# Altium schematic sheet 07 Audio.SchDoc
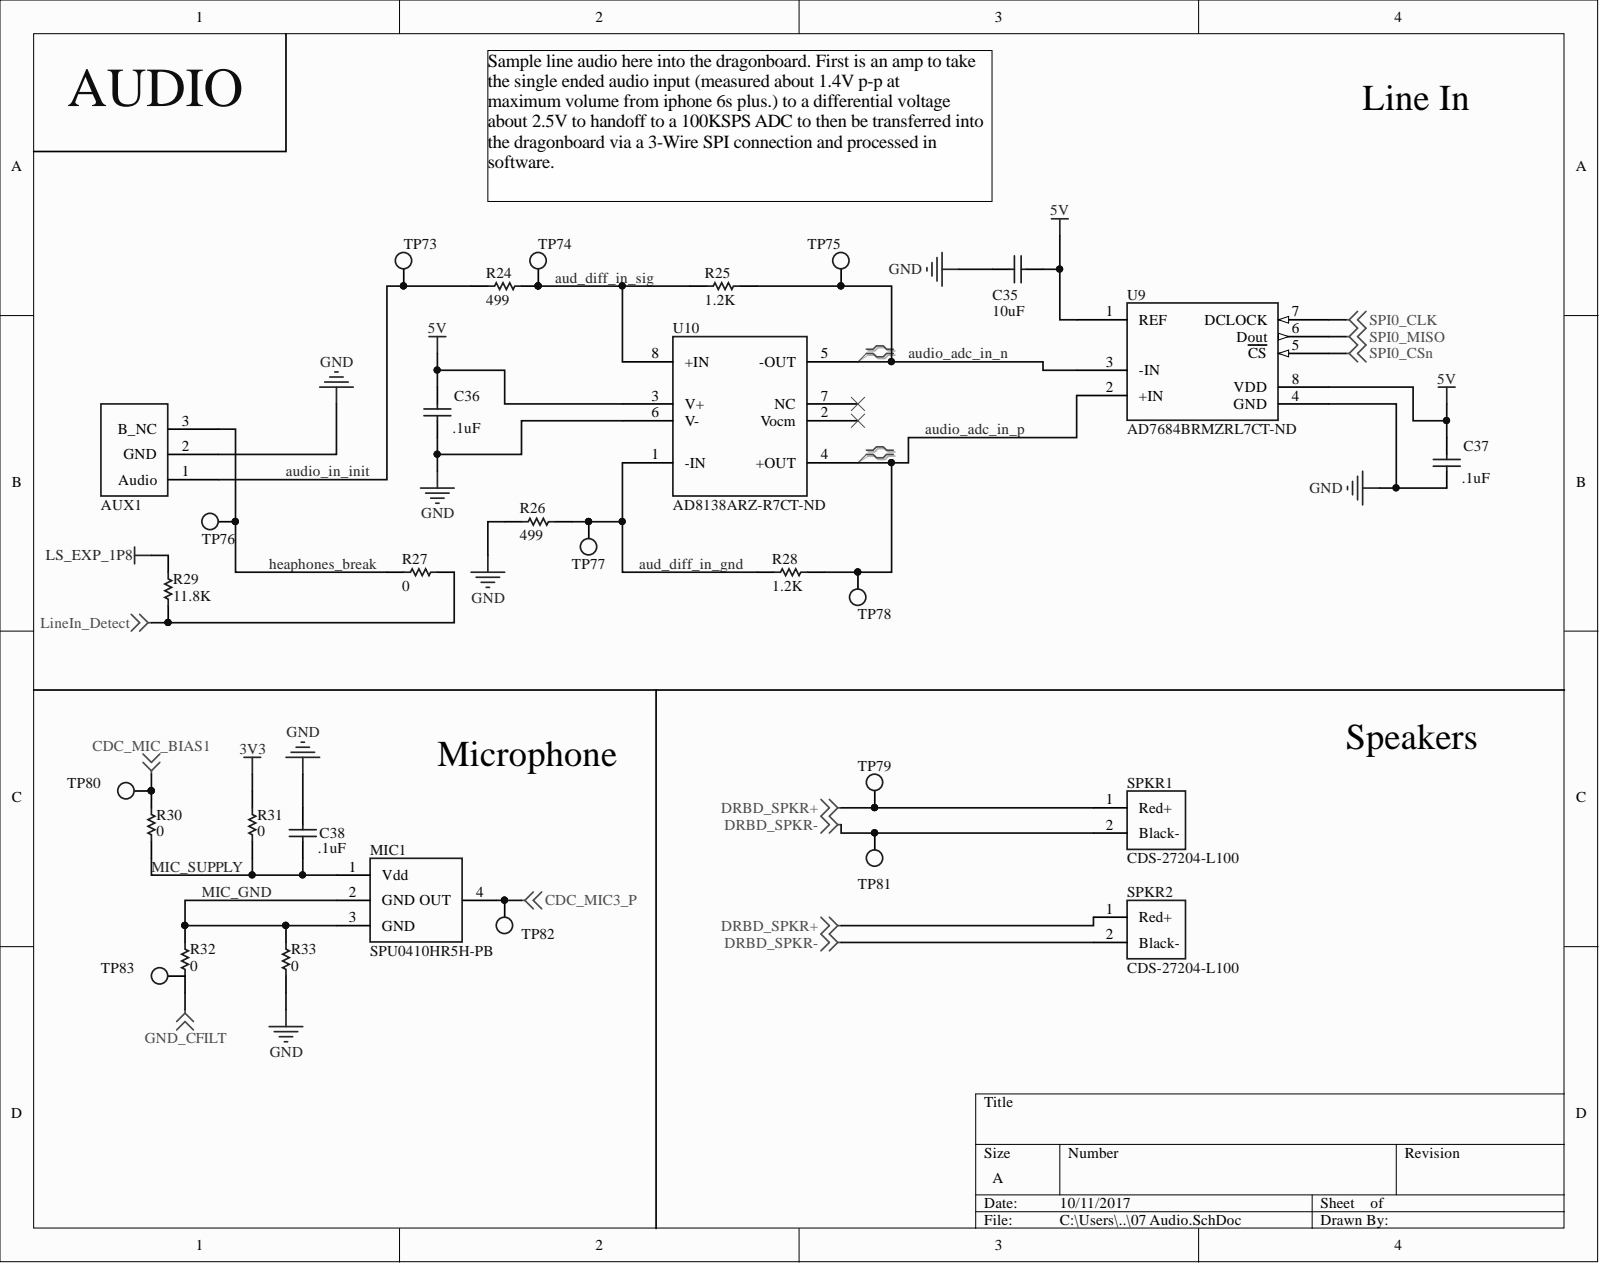
[diagram p0: 07 Audio.SchDoc]

## Parts
AUX1  CP-3523MJCT-ND  [Audio_Conn_Mono]  (value not drawn)
C35  value 10uF  Capacitance: 10uF  [CAPC2012X135N]  (hidden comment: CAP_0805_10u_16V)
C36  value .1uF  [CAPC2012X135N]  (hidden comment: CAP_0805_.1u_250V)
C37  value .1uF  [CAPC2012X135N]  (hidden comment: CAP_0805_.1u_250V)
C38  value .1uF  [CAPC2012X135N]  (hidden comment: CAP_0805_.1u_250V)
MIC1  SPU0410HR5H-PB  [MIC_FP]
R24  RES_0805_499  [RES0805]  (value not drawn)
R25  Resistance: 1.2K  [RES0805]  (hidden comment: RES_0805_1.2K)
R26  RES_0805_499  [RES0805]  (value not drawn)
R27  RES_0805_JMP  [RES0805]  (value not drawn)
R28  Resistance: 1.2K  [RES0805]  (hidden comment: RES_0805_1.2K)
R29  RES_0805_11.8K  [RES0805]  (value not drawn)
R30  RES_0805_JMP  [RES0805]  (value not drawn)
R31  RES_0805_JMP  [RES0805]  (value not drawn)
R32  RES_0805_JMP  [RES0805]  (value not drawn)
R33  RES_0805_JMP  [RES0805]  (value not drawn)
SPKR1  CDS-27204-L100  [Speaker_Header]
SPKR2  CDS-27204-L100  [Speaker_Header]
TP73  TP  [TestPoint_1206]
TP74  TP  [TestPoint_1206]
TP75  TP  [TestPoint_1206]
TP76  TP  [TestPoint_1206]
TP77  TP  [TestPoint_1206]
TP78  TP  [TestPoint_1206]
TP79  TP  [TestPoint_1206]
TP80  TP  [TestPoint_1206]
TP81  TP  [TestPoint_1206]
TP82  TP  [TestPoint_1206]
TP83  TP  [TestPoint_1206]
U9  AD7684BRMZRL7CT-ND  [MSOP8]
U10  AD8138ARZ-R7CT-ND  [SOIC127P600X175-8N]

## Nets
3V3: R31.2
5V: C35.1 C36.2 C37.2 U9.1(REF) U9.8(VDD) U10.3(V+)
CDC_MIC3_P: MIC1.4(OUT) TP82.1
CDC_MIC_BIAS1: R30.2 TP80.1
DRBD_SPKR+: SPKR1.1 SPKR2.1 TP79.1
DRBD_SPKR-: SPKR1.2 SPKR2.2 TP81.1
GND: AUX1.2 C35.2 C36.1 C37.1 C38.2 R26.1 R33.1 U9.4(GND) U10.6(V-)
GND_CFILT: R32.1
LineIn_Detect: R27.2 R29.1
LS_EXP_1P8: R29.2
SPI0_CLK: U9.7(DCLOCK)
SPI0_CSn: U9.5(CS)
SPI0_MISO: U9.6(Dout)
aud_diff_in_gnd: R26.2 R28.1 TP77.1 U10.1(-IN)
aud_diff_in_sig: R24.2 R25.1 TP74.1 U10.8(+IN)
audio_adc_in_n: R25.2 TP75.1 U9.3(-IN) U10.5(-OUT)
audio_adc_in_p: R28.2 TP78.1 U9.2(+IN) U10.4(+OUT)
audio_in_init: AUX1.1 R24.1 TP73.1
heaphones_break: AUX1.3 R27.1 TP76.1
MIC_GND: MIC1.2(GND) MIC1.3(GND) R32.2 R33.2
MIC_SUPPLY: C38.1 MIC1.1(Vdd) R30.1 R31.1

Not connected: TP83 1; U10 2(Vocm) 7(NC)

## Library data of the parts
AUX1 = CP-3523MJCT-ND: 3.50mm (0.141", 1/8", Mini Plug) - Headphone Phone Jack Mono Connector Solder; Manufacturer: CUI; Manufacturer Part Number: MJ-3523-SMT-TR; Current Rating: 1A; Gender: Female; Shielding: Unshielded; Case/Package: SMD/SMT; Component Kind: Standard; Contact Plating: Silver; Lead Free: Lead Free; Packaging: Cut Tape; Pricing 1: 1=1.02, 10=0.9, 25=0.8476, 50=0.8122, 100=0.7768, 250=0.7062 (USD); Stock 1: 44959; Supplier 1: Digi-Key; Supplier Part Number 1: CP-3523MJCT-ND; Termination: Solder; Library Name: schlib_truman.SchLib
C35 = CAP_0805_10u_16V: Cap Ceramic 10uF 16V X5R 10% SMD 0805 85C T/R; Manufacturer: Johanson Dielectrics; Manufacturer Part Number: 160R15X106KV4E; Case/Package: 0805; Case Code (Imperial): 0805; Case Code (Metric): 2012; Component Kind: Standard; ComponentLink1Description: https://datasheet.ciiva.com/26948/getdatasheetpartid-132904-26948555.pdf; ComponentLink1URL: https://datasheet.ciiva.com/26948/getdatasheetpartid-132904-26948555.pdf; Depth: 1.27mm; Dielectric: X5R; Height: 1.27mm; Length: 2.03mm; Max Operating Temperature: 85°C; Min Operating Temperature: -55°C; Mount: Surface Mount; Number of Pins: 2; Package Quantity: 2000; Packaging: Tape and Reel; Pricing 1: 1=0.55, 10=0.387, 100=0.2552, 500=0.189, 1000=0.1607 (USD); Radiation Hardening: No; REACH SVHC: No SVHC; Stock 1: 36636; Supplier 1: Digi-Key; Supplier Part Number 1: 709-1229-1-ND; Termination: SMD/SMT; Thickness: 0.06inch; Tolerance: 10%; Voltage Rating: 16V; Voltage Rating (DC): 16V; Weight: 0.000194oz; Width: 1.27mm; Library Name: schlib_truman.SchLib
C36-C38 = CAP_0805_.1u_250V: Cap Ceramic 0.1uF 250V X7T 10% SMD 0805 125C Plastic T/R; Manufacturer: TDK; Manufacturer Part Number: C2012X7T2E104K125AE; Capacitance: 100nF; Case/Package: 0805; Case Code (Imperial): 0805; Case Code (Metric): 2012; Component Kind: Standard; ComponentLink1Description: https://datasheet.ciiva.com/24219/c2012x7t2e104k125ae-265154-24219519.pdf; ComponentLink1URL: https://datasheet.ciiva.com/24219/c2012x7t2e104k125ae-265154-24219519.pdf; Composition: Ceramic; Depth: 1.25mm; Dielectric: X7T; Height: 1.25mm; Length: 2mm; Max Operating Temperature: 125°C; Min Operating Temperature: -55°C; Package Quantity: 1; Packaging: Tape and Reel; Pricing 1: 1=0.37, 10=0.25, 100=0.1419, 500=0.1002, 1000=0.0877 (USD); REACH SVHC: No SVHC; Stock 1: 30376; Supplier 1: Digi-Key; Supplier Part Number 1: 445-8883-1-ND; Termination: SMD/SMT; Thickness: 0.049inch; Tolerance: 10%; Voltage Rating: 250V; Voltage Rating (DC): 250V; Weight: 0.000194oz; Width: 0.05inch; Library Name: schlib_truman.SchLib
MIC1 = SPU0410HR5H-PB: MIC MEMS ANALOG OMNI -42DB; Manufacturer: Knowles; Direction: Omnidirectional; Impedance: 300R; Operating Supply Current: 250uA; Shape: Rectangular; Signal to Noise Ratio (SNR): 59dB; Voltage Rating: 3.6V; Component Kind: Standard; ComponentLink1Description: https://datasheet.ciiva.com/5300/spu0410hr5h-58393-5300133.pdf; ComponentLink1URL: https://datasheet.ciiva.com/5300/spu0410hr5h-58393-5300133.pdf; ComponentLink2Description: https://datasheet.ciiva.com/1/spu0410hr5h-pb-1958.pdf; ComponentLink2URL: https://datasheet.ciiva.com/1/spu0410hr5h-pb-1958.pdf; ComponentLink3Description: https://datasheet.ciiva.com/1/spu0410hr5h-pb-1959.pdf; ComponentLink3URL: https://datasheet.ciiva.com/1/spu0410hr5h-pb-1959.pdf; Depth: 1.2mm; Height: 1.2mm; Length: 3.76mm; Manufacturer Part Number: SPU0410HR5H-PB; Package Quantity: 1200; Packaging: Tape and Reel; Pricing 1: 1=0.94, 10=0.806, 25=0.6476, 50=0.5756, 100=0.5036, 250=0.4892, 500=0.4748 (USD); Stock 1: 176050; Supplier 1: Digi-Key; Supplier Part Number 1: 423-1138-1-ND; Termination: SMD/SMT; Width: 2.95mm; Library Name: schlib_truman.SchLib
R24 R26 = RES_0805_499: Res Thick Film 0805 499 Ohm 1% 0.125W(1/8W) ±100ppm/C Molded SMD Automotive T/R; Manufacturer: Stackpole Electronics; Manufacturer Part Number: RMCF0805FT499R; Max Power Dissipation: 125mW; Resistance: 499; Temperature Coefficient: 100ppm/°C; Case/Package: 0805; Case Code (Imperial): 0805; Case Code (Metric): 2012; Component Kind: Standard; ComponentLink1Description: https://www.verical.com/server-webapp/html/getDatasheet?partID=363156; ComponentLink1URL: https://www.verical.com/server-webapp/html/getDatasheet?partID=363156; ComponentLink2Description: http://components.arrow.com/part/dataview/44988387S7171819N1174; ComponentLink2URL: http://components.arrow.com/part/dataview/44988387S7171819N1174; ComponentLink3Description: https://www.seielect.com/catalog/SEI-RMCF_RMCP.pdf; ComponentLink3URL: https://www.seielect.com/catalog/SEI-RMCF_RMCP.pdf; ComponentLink4Description: http://www.seielect.com/Catalog/SEI-RMC.pdf; ComponentLink4URL: http://www.seielect.com/Catalog/SEI-RMC.pdf; Composition: Thick Film; Contact Plating: Tin,Matte; Depth: 1.25mm; Height: 0.026inch; Lead Free: Lead Free; Length: 2mm; Max Operating Temperature: 155°C; Military Standard: Not; Min Operating Temperature: -55°C; Mount: Surface Mount; Number of Terminations: 2; Packaging: Tape and Reel; Power Rating: 125mW; Pricing 1: 1=0.1, 10=0.023, 100=0.0095, 1000=0.0043, 2500=0.0037 (USD); Radiation Hardening: No; Stock 1: 258760; Supplier 1: Digi-Key; Supplier Part Number 1: RMCF0805FT499RCT-ND; Tolerance: 1%; Width: 0.049inch; Library Name: schlib_truman.SchLib
R25 R28 = RES_0805_1.2K: Res Thick Film 0805 1.2K Ohm 5% 0.125W(1/8W) ±200ppm/C Molded SMD Automotive T/R; Manufacturer: Stackpole Electronics; Manufacturer Part Number: RMCF0805JT1K20; Max Power Dissipation: 125mW; Temperature Coefficient: 200ppm/°C; Case/Package: 0805; Case Code (Imperial): 0805; Case Code (Metric): 2012; Component Kind: Standard; ComponentLink1Description: https://www.verical.com/server-webapp/html/getDatasheet?partID=408272; ComponentLink1URL: https://www.verical.com/server-webapp/html/getDatasheet?partID=408272; ComponentLink2Description: http://components.arrow.com/part/dataview/50565311S7077410N1174; ComponentLink2URL: http://components.arrow.com/part/dataview/50565311S7077410N1174; ComponentLink3Description: https://www.seielect.com/catalog/SEI-RMCF_RMCP.pdf; ComponentLink3URL: https://www.seielect.com/catalog/SEI-RMCF_RMCP.pdf; Composition: Thick Film; Contact Plating: Tin,Matte; Depth: 1.25mm; Height: 0.026inch; Lead Free: Lead Free; Length: 0.079inch; Max Operating Temperature: 155°C; Military Standard: Not; Min Operating Temperature: -55°C; Mount: Surface Mount; Number of Terminations: 2; Packaging: Tape and Reel; Pricing 1: 1=0.1, 10=0.017, 100=0.0067, 1000=0.003, 2500=0.0026 (USD); Radiation Hardening: No; Stock 1: 133190; Supplier 1: Digi-Key; Supplier Part Number 1: RMCF0805JT1K20CT-ND; Tolerance: 5%; Width: 0.049inch; Library Name: schlib_truman.SchLib
R27 R30-R33 = RES_0805_JMP: RES, THICK FILM, 0R, 0.125W, 0805; Manufacturer: Yageo; Manufacturer Part Number: RC0805JR-070RL; Power Rating: 125mW; Resistance: 0mR; Temperature Coefficient: 200ppm/°C; Tolerance: 5%; Voltage Rating: 150V; : 0; Case/Package: 0805; Case Code (Imperial): 0805; Case Code (Metric): 2012; Component Kind: Standard; ComponentLink1Description: https://datasheet.ciiva.com/7941/1738694-7941861.pdf; ComponentLink1URL: https://datasheet.ciiva.com/7941/1738694-7941861.pdf; ComponentLink2Description: https://datasheet.ciiva.com/7941/1738694-7941862.pdf; ComponentLink2URL: https://datasheet.ciiva.com/7941/1738694-7941862.pdf; ComponentLink3Description: https://datasheet.ciiva.com/11819/0900766b8106beab-11819430.pdf; ComponentLink3URL: https://datasheet.ciiva.com/11819/0900766b8106beab-11819430.pdf; ComponentLink4Description: https://datasheet.ciiva.com/7941/1738694-7941863.pdf; ComponentLink4URL: https://datasheet.ciiva.com/7941/1738694-7941863.pdf; ComponentLink5Description: https://datasheet.ciiva.com/15190/getdatasheetpartid-330685-15190028.pdf; ComponentLink5URL: https://datasheet.ciiva.com/15190/getdatasheetpartid-330685-15190028.pdf; Composition: Thick Film; Contact Plating: Tin,Matte; Depth: 1.25mm; Height: 0.5mm; Lead Free: Lead Free; Length: 2mm; Max Operating Temperature: 155°C; Military Standard: Not; Min Operating Temperature: -55°C; Number of Pins: 2; Packaging: Tape and Reel; Pricing 1: 1=0.1, 10=0.018, 25=0.0128, 50=0.0096, 100=0.0071, 250=0.0054, 500=0.0044, 1000=0.0032 (USD); Radiation Hardening: No; REACH SVHC: Unknown; Stock 1: 6469744; Supplier 1: Digi-Key; Supplier Part Number 1: 311-0.0ARCT-ND; Termination: SMD/SMT; Voltage Rating (DC): 150V; Width: 1.25mm; Library Name: schlib_truman.SchLib
R29 = RES_0805_11.8K: RES SMD 11.8K OHM 1% 1/8W 0805; Manufacturer: Yageo; Manufacturer Part Number: RC0805FR-0711K8L; Max Power Dissipation: 125mW; Resistance: 11.8KR; Temperature Coefficient: 100ppm/°C; Voltage Rating: 150V; : 11.8K; Case/Package: 0805; Case Code (Imperial): 0805; Case Code (Metric): 2012; Component Kind: Standard; ComponentLink1Description: https://datasheet.ciiva.com/15189/getdatasheetpartid-498796-15189893.pdf; ComponentLink1URL: https://datasheet.ciiva.com/15189/getdatasheetpartid-498796-15189893.pdf; ComponentLink2Description: https://datasheet.ciiva.com/2509/pyu-r-int-thick-7-2509453.pdf; ComponentLink2URL: https://datasheet.ciiva.com/2509/pyu-r-int-thick-7-2509453.pdf; ComponentLink3Description: https://datasheet.ciiva.com/2509/pyu-r-int-thick-7-2509454.pdf; ComponentLink3URL: https://datasheet.ciiva.com/2509/pyu-r-int-thick-7-2509454.pdf; ComponentLink4Description: https://datasheet.ciiva.com/2509/pyu-r-int-thick-7-2509455.pdf; ComponentLink4URL: https://datasheet.ciiva.com/2509/pyu-r-int-thick-7-2509455.pdf; Composition: Thick Film; Contact Plating: Tin,Lead,Matte; Depth: 1.25mm; Height: 0.024inch; Lead Free: Lead Free; Length: 2mm; Max Operating Temperature: 155°C; Military Standard: Not; Min Operating Temperature: -55°C; Number of Terminations: 2; Package Quantity: 1; Packaging: Tape and Reel; Power Rating: 125mW; Pricing 1: 1=0.1, 10=0.022, 100=0.0088, 1000=0.004, 2500=0.0034 (USD); Radiation Hardening: No; Stock 1: 69614; Supplier 1: Digi-Key; Supplier Part Number 1: 311-11.8KCRCT-ND; Termination: SMD/SMT; Tolerance: 1%; Voltage Rating (DC): 150V; Width: 0.049inch; Library Name: schlib_truman.SchLib
SPKR1 SPKR2 = CDS-27204-L100: 4 Ohm Magnetic Speaker 2W 20Hz ~ 20kHz Top Rectangular 93dB; Manufacturer: CUI; Impedance: 4R; Max Power Dissipation: 2.5W; Component Kind: Standard; Height: 6.1mm; Lead Free: Lead Free; Length: 27mm; Manufacturer Part Number: CDS-27204-L100; Power Rating: 2W; Pricing 1: 1=3.55, 10=3.179, 25=2.8052, 50=2.5246, 100=2.4871, 250=2.3842, 500=2.2908, 1000=2.244 (USD); Shape: Rectangular; Stock 1: 445; Supplier 1: Digi-Key; Supplier Part Number 1: 102-3547-ND; Termination: Wire Leads; Width: 20mm; Library Name: schlib_truman.SchLib
TP73-TP83 = TP: Testpoint_1206; Manufacturer: TE Connectivity; Manufacturer Part Number: 1625854-4; Resistance: 0mR; Case/Package: 1206; Colour: Natural; Component Kind: Standard; ComponentLink1Description: https://datasheet.ciiva.com/16499/1625854-4-16499923.pdf; ComponentLink1URL: https://datasheet.ciiva.com/16499/1625854-4-16499923.pdf; ComponentLink2Description: https://datasheet.ciiva.com/6439/eng-ds-1773266-a-6439173.pdf; ComponentLink2URL: https://datasheet.ciiva.com/6439/eng-ds-1773266-a-6439173.pdf; ComponentLink3Description: https://datasheet.ciiva.com/6439/eng-ds-1773266-a-6439161.pdf; ComponentLink3URL: https://datasheet.ciiva.com/6439/eng-ds-1773266-a-6439161.pdf; ComponentLink4Description: https://datasheet.ciiva.com/36535/eng-ds-1773266-a-36535790.pdf; ComponentLink4URL: https://datasheet.ciiva.com/36535/eng-ds-1773266-a-36535790.pdf; Depth: 1.6mm; Lead Free: Lead Free; Length: 3.2mm; Max Operating Temperature: 125°C; Military Standard: Not; Min Operating Temperature: -55°C; Package Quantity: 2000; Packaging: Tape and Reel; Pricing 1: 1=0.28, 10=0.261, 25=0.224, 50=0.1902, 100=0.1828, 250=0.1641, 500=0.1567, 1000=0.1306 (USD); Radiation Hardening: No; Stock 1: 23359; Supplier 1: Digi-Key; Supplier Part Number 1: A106146CT-ND; Library Name: schlib_truman.SchLib
U9 = AD7684BRMZRL7CT-ND: IC ADC 16BIT 100KSPS DIFF 8MSOP; Manufacturer: Analog Devices; Full Scale Error: 15LSB; Input Capacitance: 30pF; Integral Nonlinearity (INL): 3LSB; Interface: SPI; Max Input Voltage: 5.5V; Max Power Dissipation: 6mW; Min Input Voltage: 500mV; Min Supply Voltage: 2.7V; Number of A/D Converters: 1; Number of Bits: 16; Polarity: Bipolar; Power Consumption: 4mW; Resolution: 16b; Sampling Rate: 100KS/s; Signal to Noise Ratio (SNR): 91dB; Supply Type: Single; Supply Voltage: 5V; Case/Package: MSOP; Component Kind: Standard; ComponentLink1Description: https://datasheet.ciiva.com/16322/ad7684-278579-16322642.pdf; ComponentLink1URL: https://datasheet.ciiva.com/16322/ad7684-278579-16322642.pdf; ComponentLink2Description: https://datasheet.ciiva.com/8195/ad7684-8195814.pdf; ComponentLink2URL: https://datasheet.ciiva.com/8195/ad7684-8195814.pdf; ComponentLink3Description: https://datasheet.ciiva.com/1287/ad7684-1287305.pdf; ComponentLink3URL: https://datasheet.ciiva.com/1287/ad7684-1287305.pdf; ComponentLink4Description: https://datasheet.ciiva.com/16612/ad7684-16612158.pdf; ComponentLink4URL: https://datasheet.ciiva.com/16612/ad7684-16612158.pdf; Contact Plating: Gold; Height: 0.85mm; Lead Free: Contains Lead; Length: 3mm; Manufacturer Part Number: AD7684BRMZRL7; Max Operating Temperature: 85°C; Max Supply Voltage: 5.5V; Min Operating Temperature: -40°C; Number of Analog Inputs: 1; Number of Channels: 1; Number of Converters: 1; Number of Elements: 1; Number of Inputs: 1; Number of Pins: 8; Package Quantity: 1000; Packaging: Tape and Reel; Power Dissipation: 6mW; Pricing 1: 1=14.81, 10=13.613, 100=11.4968 (USD); Stock 1: 2500; Supplier 1: Digi-Key; Supplier Part Number 1: AD7684BRMZRL7CT-ND; Width: 3mm; Library Name: schlib_truman.SchLib
U10 = AD8138ARZ-R7CT-ND: SP Amp Differential ADC Driver Single ±5.5V/11V 8-Pin SOIC N T/R; Manufacturer: Analog Devices; -3db Bandwidth: 320MHz; Common Mode Rejection Ratio: 70dB; Input Bias Current: 7uA; Input Offset Voltage (Vos): 1mV; Input Resistance: 6MR; Max Dual Supply Voltage: 5.5V; Max Power Dissipation: 550mW; Max Supply Voltage: 11V; Min Dual Supply Voltage: 1.4V; Min Supply Voltage: 2.7V; Nominal Supply Current: 20mA; Number of Channels: 1; Slew Rate: 1150V/µs; Voltage Gain: 0.028dB; Bandwidth: 320MHz; Case/Package: SOIC; Component Kind: Standard; ComponentLink1Description: https://datasheet.ciiva.com/2975/ad8138-2975957.pdf; ComponentLink1URL: https://datasheet.ciiva.com/2975/ad8138-2975957.pdf; ComponentLink2Description: https://datasheet.ciiva.com/14848/ad8138-14848182.pdf; ComponentLink2URL: https://datasheet.ciiva.com/14848/ad8138-14848182.pdf; ComponentLink3Description: https://datasheet.ciiva.com/7615/ad8138-7615959.pdf; ComponentLink3URL: https://datasheet.ciiva.com/7615/ad8138-7615959.pdf; ComponentLink4Description: https://datasheet.ciiva.com/14848/ad8138-14848183.pdf; ComponentLink4URL: https://datasheet.ciiva.com/14848/ad8138-14848183.pdf; ComponentLink5Description: https://datasheet.ciiva.com/17371/ad8138-17371687.pdf; ComponentLink5URL: https://datasheet.ciiva.com/17371/ad8138-17371687.pdf; ComponentLink6Description: https://datasheet.ciiva.com/15128/getdatasheetpartid-86354-15128216.pdf; ComponentLink6URL: https://datasheet.ciiva.com/15128/getdatasheetpartid-86354-15128216.pdf; ComponentLink7Description: https://datasheet.ciiva.com/2975/ad8138-2975645.pdf; ComponentLink7URL: https://datasheet.ciiva.com/2975/ad8138-2975645.pdf; ComponentLink8Description: https://datasheet.ciiva.com/15382/ad8138-246651-15382031.pdf; ComponentLink8URL: https://datasheet.ciiva.com/15382/ad8138-246651-15382031.pdf; Gain Bandwidth Product: 320MHz; Lead Free: Contains Lead; Manufacturer Part Number: AD8138ARZ-R7; Max Operating Temperature: 85°C; Min Operating Temperature: -40°C; Mount: Surface Mount; Number of Circuits: 1; Number of Elements: 1; Number of Pins: 8; Operating Supply Current: 20mA; Package Quantity: 1000; Packaging: Tape and Reel; Power Dissipation: 550mW; Power Supply Rejection Ratio (PSRR): 70dB; Pricing 1: 1=9.26, 10=8.365, 100=6.9255, 500=6.0306 (USD); Radiation Hardening: No; Stock 1: 0; Supplier 1: Digi-Key; Supplier Part Number 1: AD8138ARZ-R7CT-ND; Library Name: schlib_truman.SchLib
